# Altium schematic sheet CAN.SchDoc
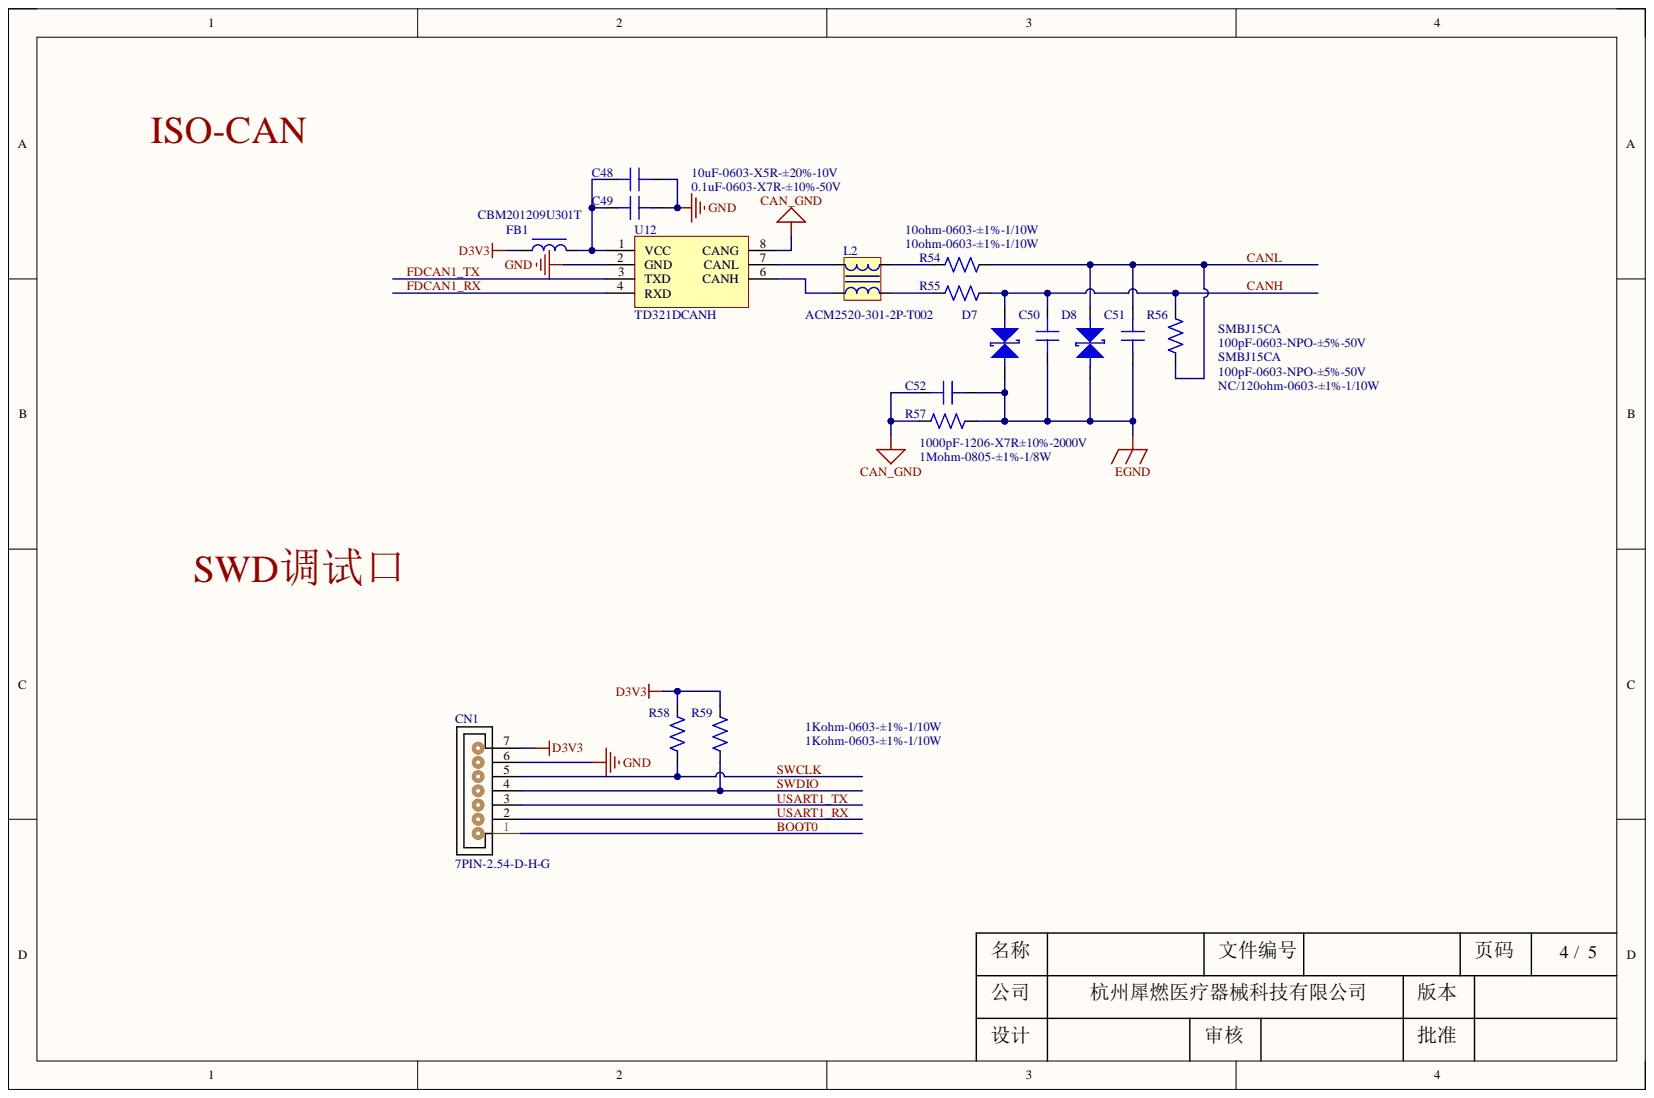
[diagram p0: CAN.SchDoc]

## Parts
C48  0.1uF-0603-X7R-±10%-50V  [C0603]
C49  10uF-0603-X5R-±20%-10V  [C0603]
C50  100pF-0603-NPO-±5%-50V  [C0603]
C51  100pF-0603-NPO-±5%-50V  [C0603]
C52  1000pF-1206-X7R±10%-2000V  [C1206]
CN1  7PIN-2.54-D-H-G  [SIP7/2.54D-1]
D7  SMBJ15CA  [DIODE-SMB-1]
D8  SMBJ15CA  [DIODE-SMB-1]
FB1  CBM201209U301T  [L0805]
L2  ACM2520-301-2P-T002  [LC4(2.5*2.0)-S]
R54  10ohm-0603-±1%-1/10W  [R0603]
R55  10ohm-0603-±1%-1/10W  [R0603]
R56  NC/120ohm-0603-±1%-1/10W  [R0603]
R57  1Mohm-0805-±1%-1/8W  [R0805]
R58  1Kohm-0603-±1%-1/10W  [R0603]
R59  1Kohm-0603-±1%-1/10W  [R0603]
U12  TD321DCANH  [DIP8-2.54_TD321DCANH]

## Nets
CAN_GND: C52.1 R57.1 U12.8(CANG)
D3V3: CN1.7 FB1.1 R58.2 R59.2
EGND: C50.1 C51.1 C52.2 D7.A D8.A R57.2
GND: C48.1 C49.1 CN1.6 U12.2(GND)
BOOT0: CN1.1
CANH: C50.2 D7.K R55.2 R56.1
CANL: C51.2 D8.K R54.2 R56.2
FDCAN1_RX: U12.4(RXD)
FDCAN1_TX: U12.3(TXD)
SWCLK: CN1.5 R58.1
SWDIO: CN1.4 R59.1
USART1_RX: CN1.2
USART1_TX: CN1.3
NetC48_2: C48.2 C49.2 FB1.2 U12.1(VCC)
NetL2_1: L2.1 R54.1
NetL2_2: L2.2 R55.1
NetL2_3: L2.3 U12.6(CANH)
NetL2_4: L2.4 U12.7(CANL)

## Library data of the parts
C48 = 0.1uF-0603-X7R-±10%-50V-D: CAP; Component Kind: Standard; Library Name: RCL.SchLib
C49 = 10uF-0603-X5R-±20%-10V-D: Component Kind: Standard; Library Name: RCL.SchLib
C50 C51 = 100pF-0603-NPO-±5%-50V-D: Component Kind: Standard; Library Name: RCL.SchLib
C52 = 1000pF-1206-X7R±10%-2000V-D: Component Kind: Standard; Library Name: RCL.SchLib
CN1 = 7PIN-2.54-D-H-G-DHMI: Component Kind: Standard; Library Name: Connector.SchLib
D7 D8 = SMBJ15CA-D: Component Kind: Standard; Library Name: Semiconductor.SchLib
FB1 = CBM201209U301T-D: Component Kind: Standard; Library Name: RCL.SchLib
L2 = ACM2520-301-2P-T002-D: 共模电感; Component Kind: Standard; Library Name: RCL.SchLib
R54 R55 = 10ohm-0603-±1%-1/10W-D: Component Kind: Standard; Library Name: RCL.SchLib
R56 = 120ohm-0603-±1%-1/10W-D: Component Kind: Standard; Library Name: RCL.SchLib
R57 = 1Mohm-0805-±1%-1/8W-D: RES; Component Kind: Standard; Library Name: RCL.SchLib
R58 R59 = 1Kohm-0603-±1%-1/10W-D: Component Kind: Standard; Library Name: RCL.SchLib
U12 = TD321DCANH: Component Kind: Standard; Library Name: check.SchLib
